# Altium schematic sheet IO_4_20mA.SchDoc
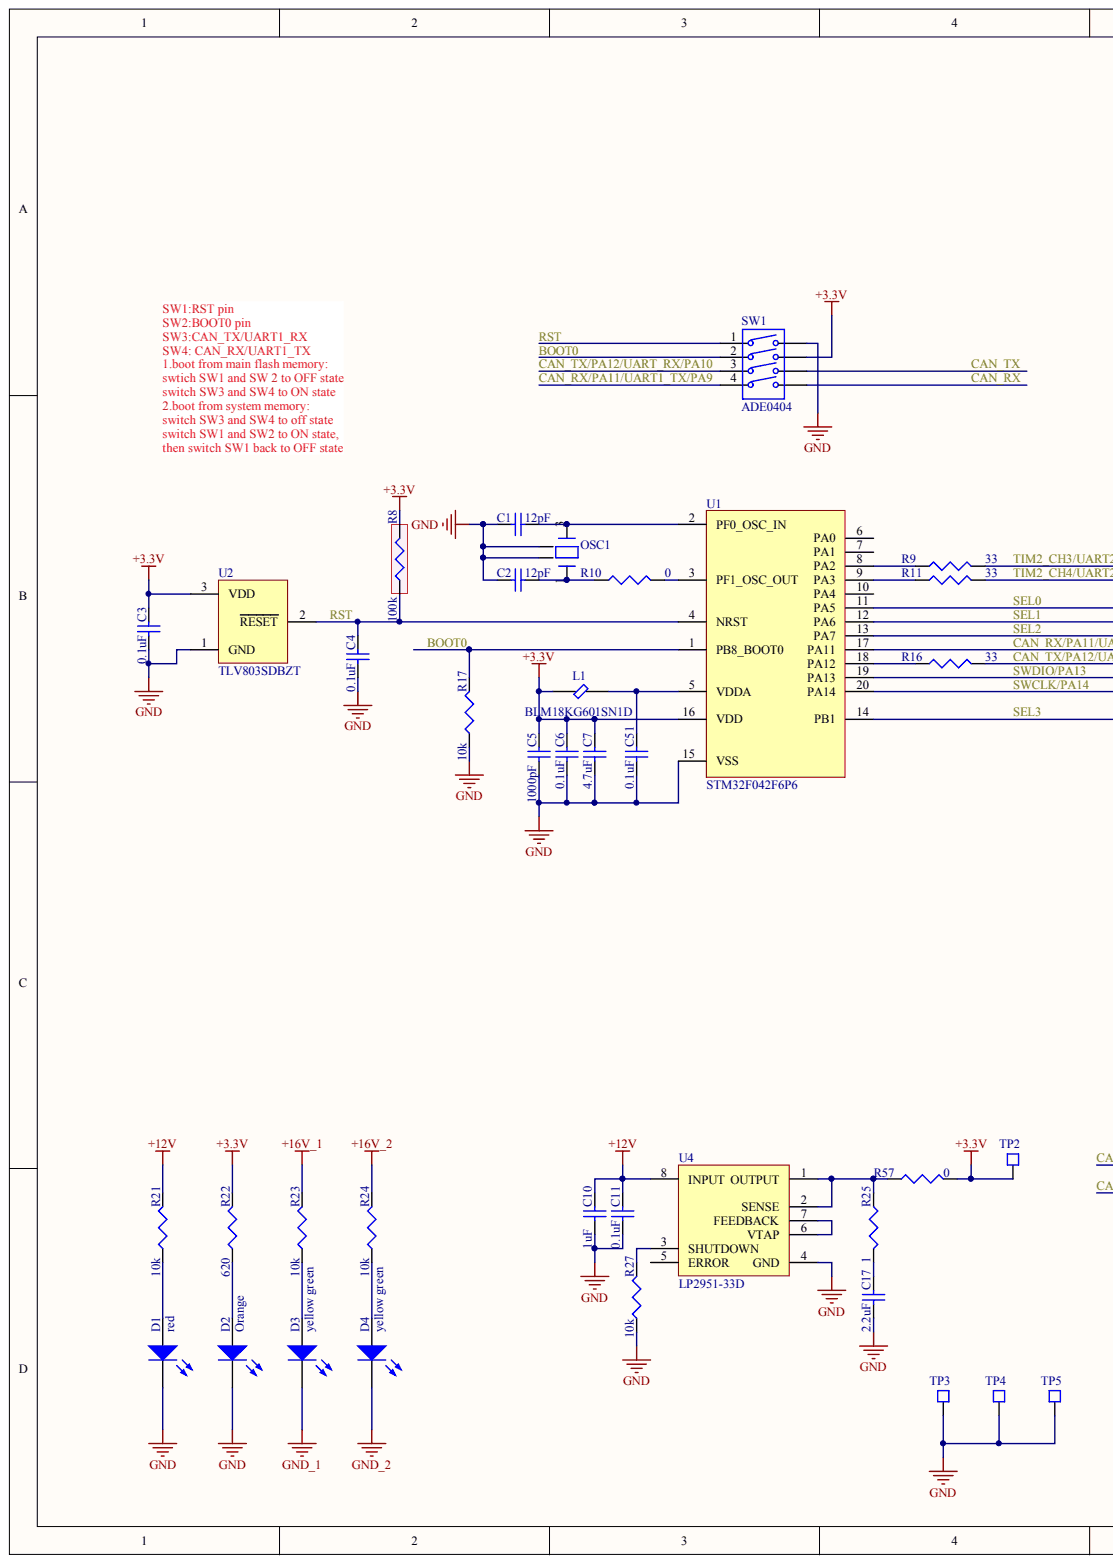
[diagram p0: IO_4_20mA.SchDoc, left]
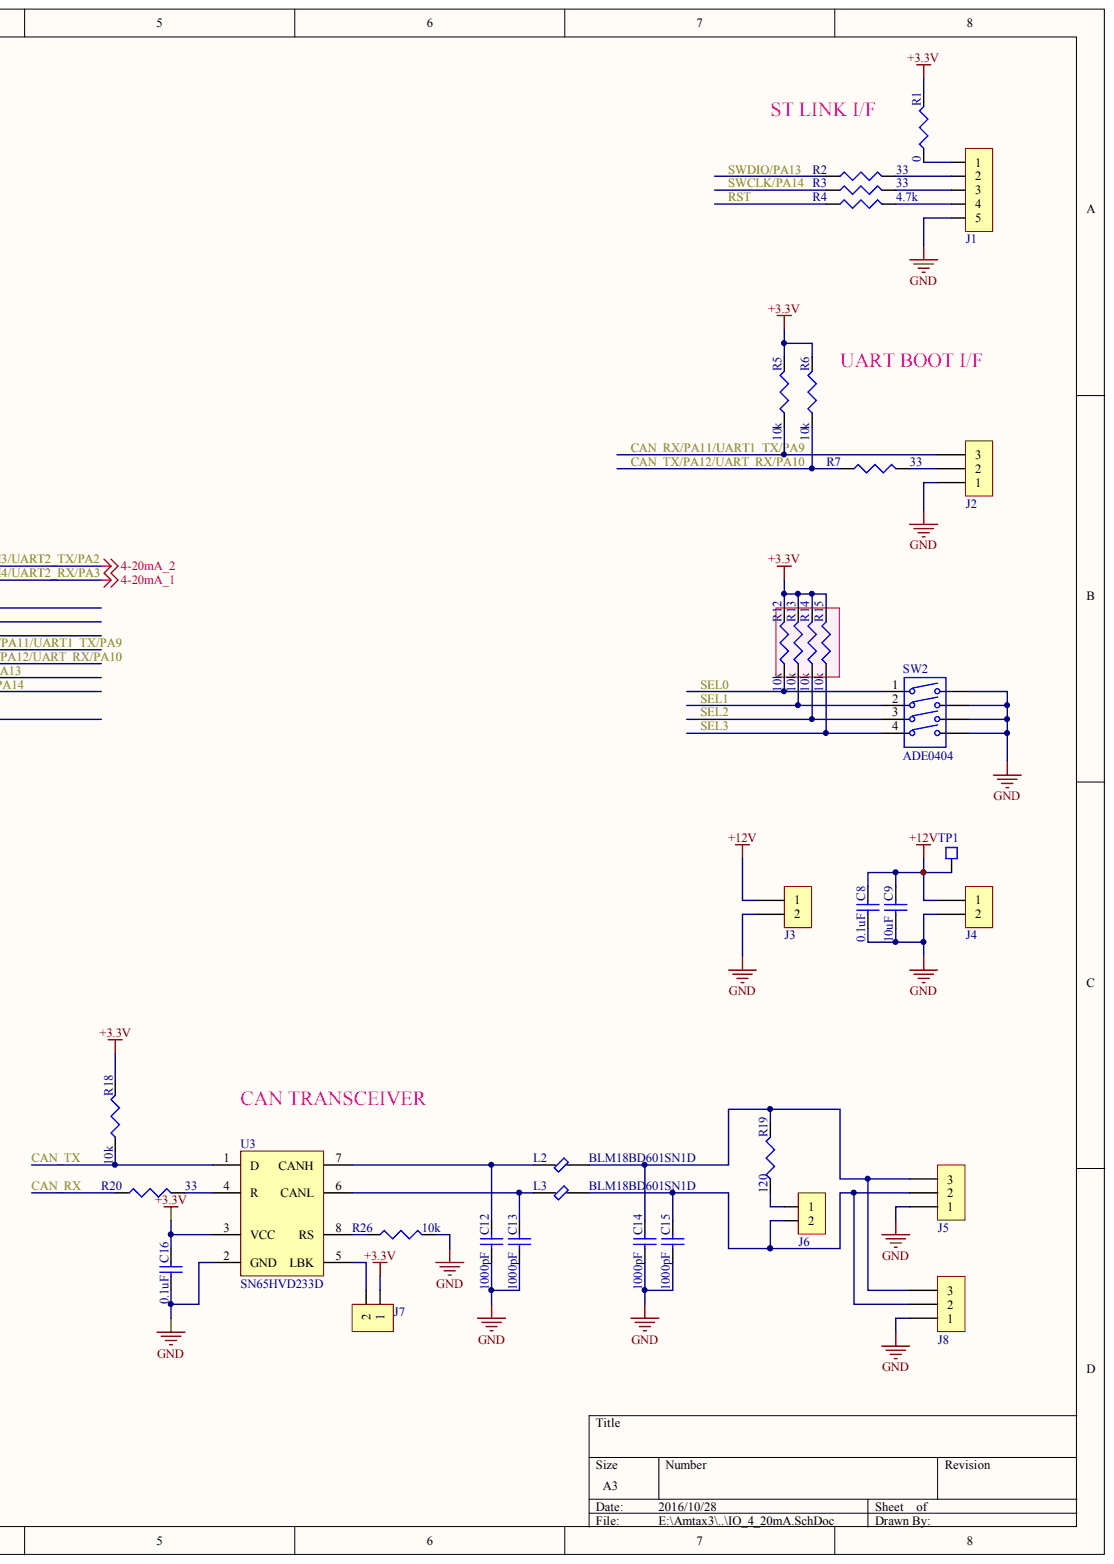
[diagram p1: IO_4_20mA.SchDoc, right]

## Parts
C1  value 12pF  [CAP0603(1608)]  (hidden comment: GRM1885C1H120JA01D)
C2  value 12pF  [CAP0603(1608)]  (hidden comment: GRM1885C1H120JA01D)
C3  value 0.1uF  [CAP0603(1608)]  (hidden comment: GRM188R71H104KA93D)
C4  value 0.1uF  [CAP0603(1608)]  (hidden comment: GRM188R71H104KA93D)
C5  value 1000pF  [CAP0603(1608)]  (hidden comment: GRM188R71H102KA01D)
C6  value 0.1uF  [CAP0603(1608)]  (hidden comment: GRM188R71H104KA93D)
C7  value 4.7uF  [CAP1206(3216)]  (hidden comment: GRM31CR71E475KA88L)
C8  value 0.1uF  [CAP0603(1608)]  (hidden comment: GRM188R71H104KA93D)
C9  value 10uF  [CAP1206(3216)]  (hidden comment: GRM31CR61E106KA12L)
C10  value 1uF  [CAP0805(2012)]  (hidden comment: GRM21BR71H105KA12L)
C11  value 0.1uF  [CAP0603(1608)]  (hidden comment: GRM188R71H104KA93D)
C12  value 1000pF  [CAP0603(1608)]  (hidden comment: GRM188R71H102KA01D)
C13  value 1000pF  [CAP0603(1608)]  (hidden comment: GRM188R71H102KA01D)
C14  value 1000pF  [CAP0603(1608)]  (hidden comment: GRM188R71H102KA01D)
C15  value 1000pF  [CAP0603(1608)]  (hidden comment: GRM188R71H102KA01D)
C16  value 0.1uF  [CAP0603(1608)]  (hidden comment: GRM188R71H104KA93D)
C17  value 2.2uF  [CAP0805(2012)]  (hidden comment: GRM21BR71A225KA01L)
C51  value 0.1uF  [CAP0603(1608)]  (hidden comment: GRM188R71H104KA93D)
D1  color: red  [ROHM SML D1 LED]  (hidden comment: SML-D12U8WT86S)
D2  color: Orange  [ROHM SML D1 LED]  (hidden comment: SML-D12D8WT86)
D3  SML-D12M8WT86Q  [ROHM SML D1 LED]  (value not drawn)
D4  SML-D12M8WT86Q  [ROHM SML D1 LED]  (value not drawn)
J1  22-28-4053  [22-28-4053]  (value not drawn)
J2  B03B-XASK-1N(LF)(SN)  [JST XA Series 3pin]  (value not drawn)
J3  691322310002  [WE 3.81mm Pitch Horizontal 2Pin]  (value not drawn)
J4  691322310002  [WE 3.81mm Pitch Horizontal 2Pin]  (value not drawn)
J5  B03B-XASK-1N(LF)(SN)  [JST XA Series 3pin]  (value not drawn)
J6  22-28-4023  [22-28-4023]  (value not drawn)
J7  22-28-4023  [22-28-4023]  (value not drawn)
J8  B03B-XASK-1N(LF)(SN)  [JST XA Series 3pin]  (value not drawn)
L1  BLM18KG601SN1D  [BLM18B]
L2  BLM18BD601SN1D  [BLM18B]
L3  BLM18BD601SN1D  [BLM18B]
OSC1  ABM8G-12.000MHZ-B4Y-T  [Crystal 3.2*2.5mm]  (value not drawn)
R1  value 0  [RES0603(1608)]  (hidden comment: RK73Z1JTTD)
R2  value 33  [RES0603(1608)]  (hidden comment: RK73B1JTTD330J)
R3  value 33  [RES0603(1608)]  (hidden comment: RK73B1JTTD330J)
R4  value 4.7k  [RES0603(1608)]  (hidden comment: RK73B1JTTD472J)
R5  value 10k  [RES0603(1608)]  (hidden comment: RK73B1JTTD103J)
R6  value 10k  [RES0603(1608)]  (hidden comment: RK73B1JTTD103J)
R7  value 33  [RES0603(1608)]  (hidden comment: RK73B1JTTD330J)
R8  value 100k  [RES0603(1608)]  (hidden comment: RK73H1JTTD1003F)
R9  value 33  [RES0603(1608)]  (hidden comment: RK73B1JTTD330J)
R10  value 0  [RES0603(1608)]  (hidden comment: RK73Z1JTTD)
R11  value 33  [RES0603(1608)]  (hidden comment: RK73B1JTTD330J)
R12  value 10k  [RES0603(1608)]  (hidden comment: RK73B1JTTD103J)
R13  value 10k  [RES0603(1608)]  (hidden comment: RK73B1JTTD103J)
R14  value 10k  [RES0603(1608)]  (hidden comment: RK73B1JTTD103J)
R15  value 10k  [RES0603(1608)]  (hidden comment: RK73B1JTTD103J)
R16  value 33  [RES0603(1608)]  (hidden comment: RK73B1JTTD330J)
R17  value 10k  [RES0603(1608)]  (hidden comment: RK73B1JTTD103J)
R18  value 10k  [RES0603(1608)]  (hidden comment: RK73B1JTTD103J)
R19  value 120  [RES0603(1608)]  (hidden comment: ERJ3EKF1200V)
R20  value 33  [RES0603(1608)]  (hidden comment: RK73B1JTTD330J)
R21  value 10k  [RES0603(1608)]  (hidden comment: RK73B1JTTD103J)
R22  value 620  [RES0603(1608)]  (hidden comment: RK73B1JTTD621J)
R23  value 10k  [RES0603(1608)]  (hidden comment: RK73B1JTTD103J)
R24  value 10k  [RES0603(1608)]  (hidden comment: RK73B1JTTD103J)
R25  value 1  [RES0603(1608)]  (hidden comment: RK73B1JTTD1R0J)
R26  value 10k  [RES0603(1608)]  (hidden comment: RK73H1JTTD1002F)
R27  value 10k  [RES0603(1608)]  (hidden comment: RK73B1JTTD103J)
R57  value 0  [RES0805(2012)]  (hidden comment: RK73Z2ATTD)
SW1  ADE0404  [ADE0404]
SW2  ADE0404  [ADE0404]
TP1  TEST-1(R)  [TEST PIN]  (value not drawn)
TP2  TEST-1(R)  [TEST PIN]  (value not drawn)
TP3  TEST-1-BK  [TEST PIN]  (value not drawn)
TP4  TEST-1-BK  [TEST PIN]  (value not drawn)
TP5  TEST-1-BK  [TEST PIN]  (value not drawn)
U1  STM32F042F6P6  [TSSOP20(STM32F042F6P6)]
U2  TLV803SDBZT  [SOT23-3(TLV803S)]
U3  SN65HVD233D  [SOIC-8(SN65HVD23x)]
U4  LP2951-33D  [SOIC-8(1.27mm pitch LP2951-33D)]

## Nets
+3.3V: C3.2 C5.2 C6.2 C7.2 C16.2 J7.1 L1.1 R1.2 R5.2 R6.2 R8.2 R12.2 R13.2 R14.2 R15.2 R18.2 R22.2 R57.2 SW1.7 TP2.1 U1.16(VDD) U2.3 U3.3(VCC)
+12V: C8.2 C9.2 C10.2 C11.2 J3.1 J4.1 R21.2 TP1.1 U4.8(INPUT)
+16V_1: R23.2
+16V_2: R24.2
GND: C1.2 C2.2 C3.1 C4.1 C5.1 C6.1 C7.1 C8.1 C9.1 C10.1 C11.1 C12.1 C13.1 C14.1 C15.1 C16.1 C17.1 C51.1 D1.2 D2.2 J1.5 J2.1 J3.2 J4.2 J5.1 J8.1 OSC1.2 OSC1.4 R17.1 R26.2 R27.1 SW1.8 SW2.5 SW2.6 SW2.7 SW2.8 TP3.1 TP4.1 TP5.1 U1.15(VSS) U2.1 U3.2(GND) U4.4(GND)
GND_1: D3.2
GND_2: D4.2
BOOT0: R17.2 SW1.2 U1.1(PB8_BOOT0)
CAN_RX: R20.1 SW1.5
CAN_RX/PA11/UART1_TX/PA9: J2.3 R5.1 SW1.4 U1.17(PA11)
CAN_TX: R18.1 SW1.6 U3.1(D)
CAN_TX/PA12/UART_RX/PA10: R6.1 R7.1 R16.2 SW1.3
RST: C4.2 R4.1 R8.1 SW1.1 U1.4(NRST) U2.2
SEL0: R12.1 SW2.1 U1.11(PA5)
SEL1: R13.1 SW2.2 U1.12(PA6)
SEL2: R14.1 SW2.3 U1.13(PA7)
SEL3: R15.1 SW2.4 U1.14(PB1)
SWCLK/PA14: R3.1 U1.20(PA14)
SWDIO/PA13: R2.1 U1.19(PA13)
TIM2_CH3/UART2_TX/PA2: R9.2
TIM2_CH4/UART2_RX/PA3: R11.2
NetC1_1: C1.1 OSC1.3 U1.2(PF0_OSC_IN)
NetC2_1: C2.1 OSC1.1 R10.1
NetC12_2: C12.2 L2.1 U3.7(CANH)
NetC13_2: C13.2 L3.1 U3.6(CANL)
NetC14_2: C14.2 J5.3 J8.3 L2.2 R19.2
NetC15_2: C15.2 J5.2 J6.2 J8.2 L3.2
NetC17_2: C17.2 R25.2
NetC51_2: C51.2 L1.2 U1.5(VDDA)
NetD1_1: D1.1 R21.1
NetD2_1: D2.1 R22.1
NetD3_1: D3.1 R23.1
NetD4_1: D4.1 R24.1
NetJ1_1: J1.1 R1.1
NetJ1_2: J1.2 R2.2
NetJ1_3: J1.3 R3.2
NetJ1_4: J1.4 R4.2
NetJ2_2: J2.2 R7.2
NetJ6_1: J6.1 R19.1
NetJ7_2: J7.2 U3.5(LBK)
NetR9_1: R9.1 U1.8(PA2)
NetR10_2: R10.2 U1.3(PF1_OSC_OUT)
NetR11_1: R11.1 U1.9(PA3)
NetR16_1: R16.1 U1.18(PA12)
NetR20_2: R20.2 U3.4(R)
NetR25_1: R25.1 R57.1 U4.1(OUTPUT) U4.2(SENSE)
NetR26_1: R26.1 U3.8(RS)
NetR27_2: R27.2 U4.3(SHUTDOWN)
NetU4_6: U4.6(VTAP) U4.7(FEEDBACK)

Not connected: U1 6(PA0) 7(PA1) 10(PA4); U4 5(ERROR)

## Library data of the parts
C1 C2 = CAP0603 12PFD 50VDC COG: Ceramic Capacitor CAP0603 12PFD 50VDC COG; Vendor Name: MURATA; Component Kind: Standard; MPN: GRM1885C1H120JA01D; Library Name: dosing board.SchLib
C3 C4 C6 C8 C11 C16 C51 = CAP0603 0.1MFD 50VDC X7R: Ceramic Capacitor CAP0603 0.1MFD 50VDC X7R; Vendor Name: MURATA; Component Kind: Standard; MPN: GRM188R71H104KA93D; Library Name: dosing board.SchLib
C5 C12-C15 = CAP0603 1000PFD 50VDC X7R: Ceramic Capacitor CAP0603 1000PFD 50VDC X7R; Vendor Name: MURATA; Component Kind: Standard; MPN: GRM188R71H102KA01D; Library Name: dosing board.SchLib
C7 = CAP1206 4.7MFD 25VDC X7R: Ceramic Capacitor CAP1206 4.7MFD 25VDC X7R; Vendor Name: MURATA; Component Kind: Standard; MPN: GRM31CR71E475KA88L; Library Name: dosing board.SchLib
C9 = CAP1206 10MFD 25VDC X5R: Ceramic Capacitor CAP1206 10MFD 25VDC X5R; Vendor Name: MURATA; Component Kind: Standard; MPN: GRM31CR61E106KA12L; Library Name: dosing board.SchLib
C10 = CAP0805 1MFD 50VDC X7R: Ceramic Capacitor CAP0805 1MFD 50VDC X7R; Vendor Name: MURATA; Component Kind: Standard; MPN: GRM21BR71H105KA12L; Library Name: dosing board.SchLib
C17 = CAP0805 2.2MFD 10VDC X7R: Ceramic Capacitor CAP0805 2.2MFD 10VDC X7R; Vendor Name: MURATA; Component Kind: Standard; MPN: GRM21BR71A225KA01L; Library Name: dosing board.SchLib
D1 = LED0603, RED: Vendor Name: ROHM; Component Kind: Standard; Description: LED0603, RED; MPN: SML-D12U8WT86S; Library Name: dosing board.SchLib
D2 = LED0603, Orange: Vendor Name: ROHM; Component Kind: Standard; Description: LED0603, Orange; MPN: SML-D12D8WT86; Library Name: dosing board.SchLib
D3 D4 = LED0603, Yellow Green: Vendor Name: ROHM; color: yellow green; Component Kind: Standard; Description: LED0603, Yellow Green; MPN: SML-D12M8WT86Q; Library Name: dosing board.SchLib
J1 = 22-28-4053: 5 Pin 2.54mm Pitch Connector; Vendor Name: MOLEX; Component Kind: Standard; MPN: 22-28-4053; Library Name: dosing board.SchLib
J2 J5 J8 = B03B-XASK-1: 2.5mm Pitch，3 Pin Connector; Vendor Name: JST; Component Kind: Standard; MPN: B03B-XASK-1N(LF)(SN); MPN2: B03B-XASK-1(LF)(SN); Vendor Name2: JST; Library Name: dosing board.SchLib
J3 J4 = 691322310002: 2Pin，3.81mm Pitch，Connector; Vendor Name: WE; Component Kind: Standard; MPN: 691322310002; Library Name: dosing board.SchLib
J6 J7 = 22-28-4023: 2 Pin 2.54mm Pitch Connector; Vendor Name: MOLEX; Component Kind: Standard; MPN: 22-28-4023; Library Name: dosing board.SchLib
L1 = BLM18KG601SN1D: Ferrite Bead for Power Line; Vendor Name: MURATA; Component Kind: Standard; MPN: BLM18KG601SN1D; Library Name: dosing board.SchLib
L2 L3 = BLM18BD601SN1D: SMD Ferrite Bead For High Speed Signal Line; Vendor Name: MURATA; Component Kind: Standard; MPN: BLM18BD601SN1D; Library Name: dosing board.SchLib
OSC1 = ABM8G-12.000MHZ-B4Y-T: Crystal 12MHz 10PF SMD; Vendor Name: Abracon; Component Kind: Standard; MPN: ABM8G-12.000MHZ-B4Y-T; Library Name: dosing board.SchLib
R1 R10 = RES0603, 0 OHM: Vendor Name: KOA; Component Kind: Standard; Description: RES0603, 0 OHM; MPN: RK73Z1JTTD; Library Name: dosing board.SchLib
R2 R3 R7 R9 R11 R16 R20 = RES0603, 33 OHM 1/10W 5%: RES0603, 33OHM 1/10W 5%; Vendor Name: KOA; Component Kind: Standard; MPN: RK73B1JTTD330J; Library Name: dosing board.SchLib
R4 = RES0603, 4.7K OHM 1/10W 5%: Vendor Name: KOA; Component Kind: Standard; Description: RES0603, 4.7K OHM 1/10W 5%; MPN: RK73B1JTTD472J; Library Name: dosing board.SchLib
R5 R6 R12-R15 R17 R18 R21 R23 R24 R27 = RES0603, 10K OHM 1/10W 5%: Vendor Name: KOA; Component Kind: Standard; Description: RES0603, 10K OHM 1/10W 5%; MPN: RK73B1JTTD103J; Library Name: dosing board.SchLib
R8 = RES0603, 100k OHM 1/10W 1%: Vendor Name: KOA; Component Kind: Standard; Description: RES0603, 100k OHM 1/10W 1%; MPN: RK73H1JTTD1003F; Library Name: dosing board.SchLib
R19 = RES0603, 120 OHM 1/10W 1%: Vendor Name: PANASONIC; Component Kind: Standard; Description: RES0603, 120 OHM 1/10W 1%; MPN: ERJ3EKF1200V; Library Name: dosing board.SchLib
R22 = RES0603, 620 OHM 1/10W 5%: Vendor Name: KOA; Component Kind: Standard; Description: RES0603, 620 OHM 1/10W 5%; MPN: RK73B1JTTD621J; Library Name: dosing board.SchLib
R25 = RES0603, 1 OHM 1/10W 5%: Vendor Name: KOA; Component Kind: Standard; Description: RES0603, 1 OHM 1/10W 5%; MPN: RK73B1JTTD1R0J; Library Name: dosing board.SchLib
R26 = RES0603, 10k OHM 1/10W 1%: Vendor Name: KOA; Component Kind: Standard; Description: RES0603, 10k OHM 1/10W 1%; MPN: RK73H1JTTD1002F; Library Name: dosing board.SchLib
R57 = RES0805, 0 OHM: Vendor Name: KOA; Component Kind: Standard; Description: RES0805, 0 OHM; MPN: RK73Z2ATTD; Library Name: dosing board.SchLib
SW1 SW2 = ADE0404: DIP Switches ADE Series; Vendor Name: TE; Component Kind: Standard; MPN: ADE0404; Library Name: dosing board.SchLib
TP1 TP2 = TEST-1-R: Test Pin Red; Vendor Name: MULTICOMP; Component Kind: Standard; MPN: TEST-1(R); Library Name: dosing board.SchLib
TP3-TP5 = TEST-1-BK: Test Pin Black; Vendor Name: MULTICOMP; Component Kind: Standard; MPN: TEST-1-BK; Library Name: dosing board.SchLib
U1 = STM32F042F6P6: ARM-based 32-bit MCU with up to 32 KB Flash; Vendor Name: STMICROELECTRONICS; Component Kind: Standard; MPN: STM32F042F6P6; Library Name: dosing board.SchLib
U2 = TLV803S: 3-Pin Voltage Supervisors  with Active-Low, Open-Drain Reset; Vendor Name: Texas Instruments; Component Kind: Standard; MPN: TLV803SDBZT; Library Name: dosing board.SchLib
U3 = SN65HVD233D: 3.3-V CAN Bus Transceivers; Vendor Name: Texas Instruments; Component Kind: Standard; MPN: SN65HVD233D; Library Name: dosing board.SchLib
U4 = LP2951-33D: Micropower Voltage Regulators with Shutdown; Vendor Name: Texas Instruments; Component Kind: Standard; MPN: LP2951-33D; Library Name: dosing board.SchLib
